# Altium schematic sheet J19B_EM3B_PP0_AFE.SchDoc
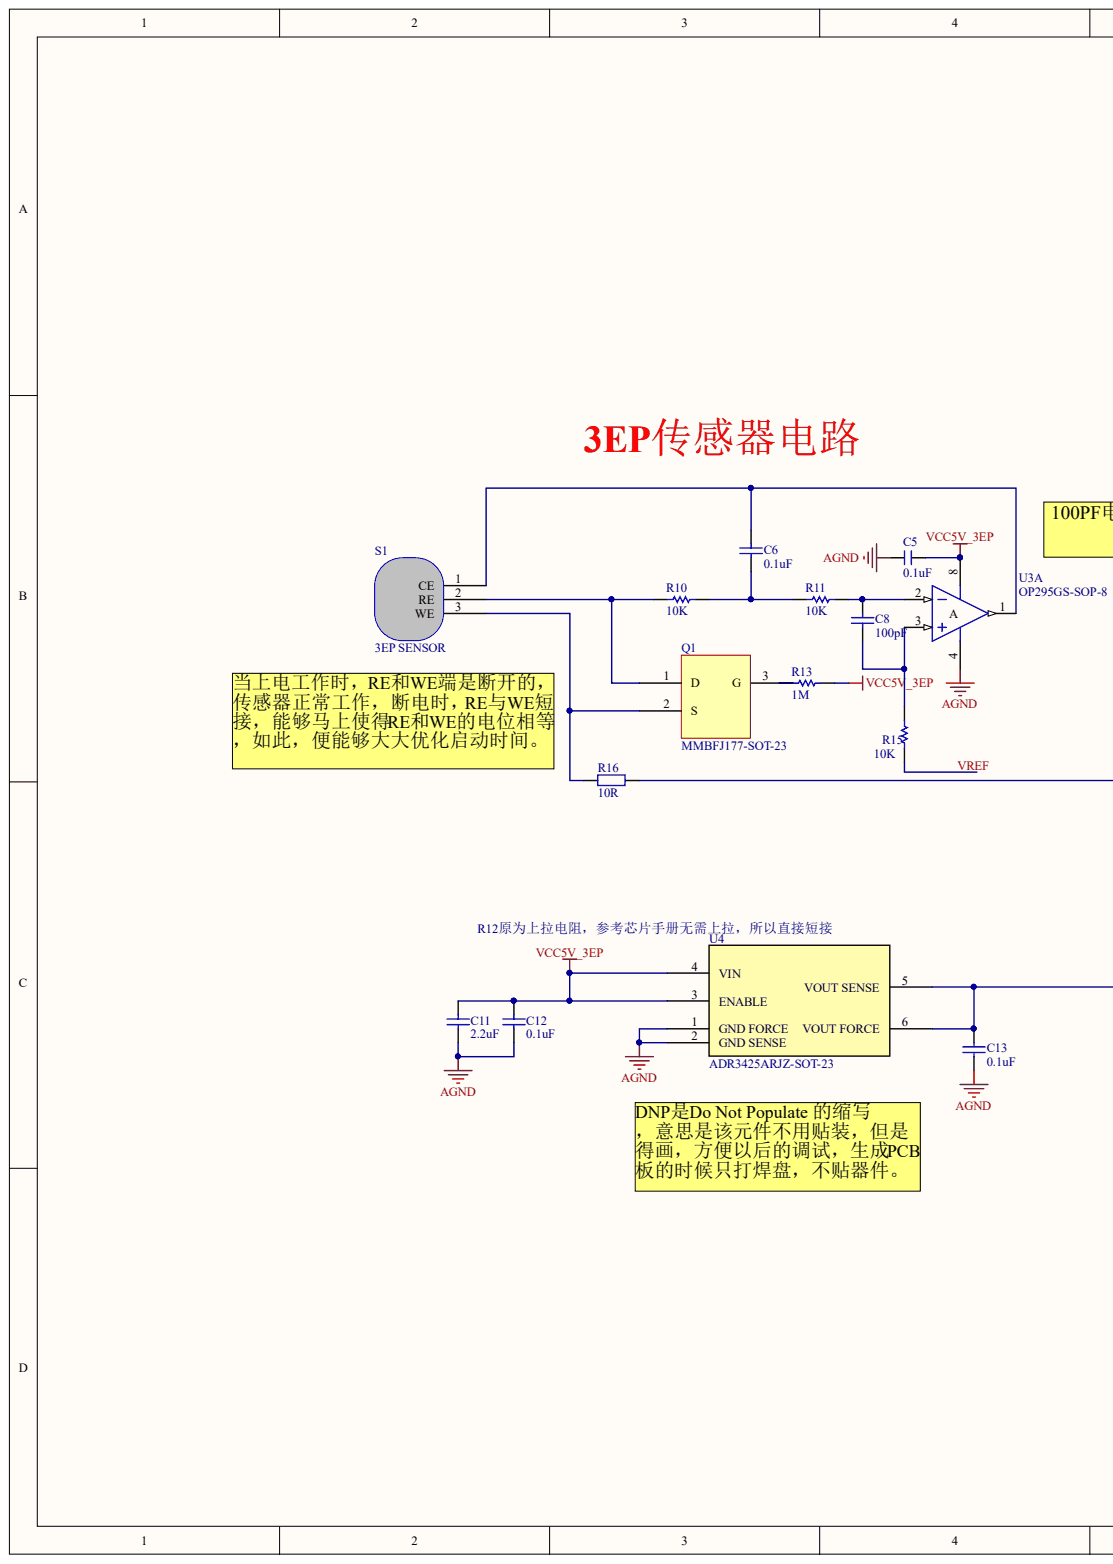
[diagram p0: J19B_EM3B_PP0_AFE.SchDoc, left]
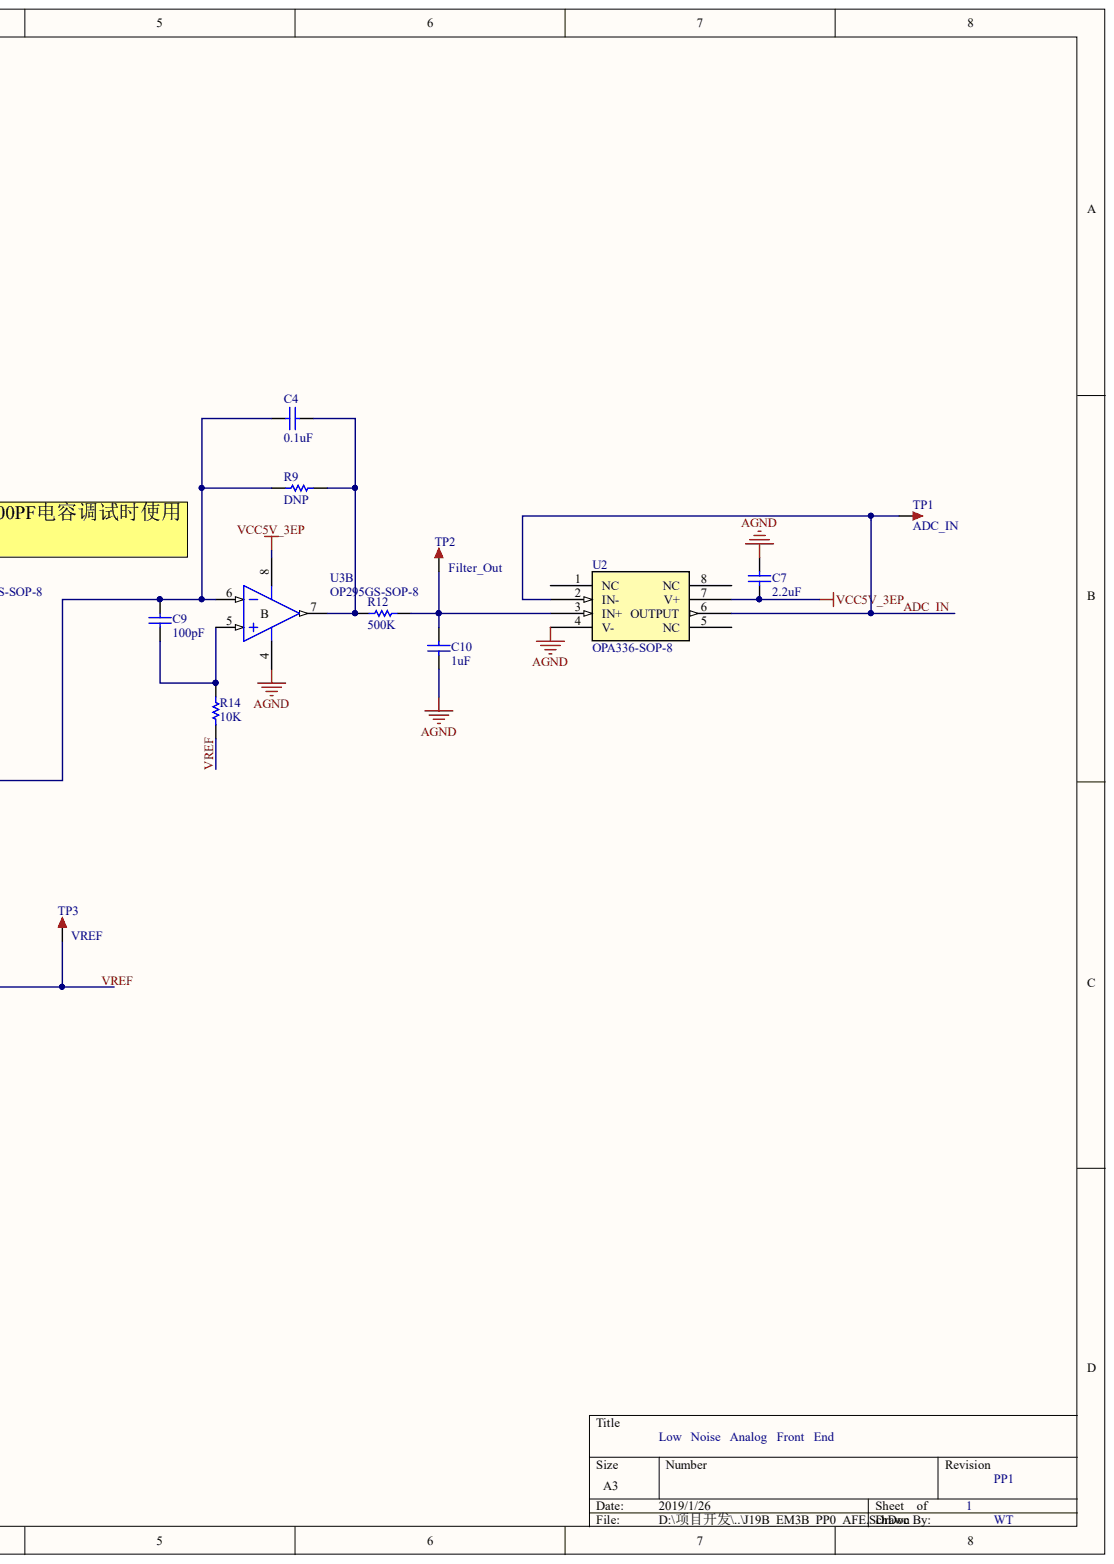
[diagram p1: J19B_EM3B_PP0_AFE.SchDoc, right]

## Parts
C4  0.1uF  [C 0603_L]
C5  0.1uF  [C 0603_L]
C6  0.1uF  [C 0603_L]
C7  2.2uF  [C 0603_L]
C8  100pF  [C 0603_L]
C9  100pF  [C 0603_L]
C10  1uF  [C 0603_L]
C11  2.2uF  [C 0603_L]
C12  0.1uF  [C 0603_L]
C13  0.1uF  [C 0603_L]
Q1  MMBFJ177-SOT-23  [SOT23-3N]
R9  DNP  [R 0603_L]
R10  10K  [R 0603_L]
R11  10K  [R 0603_L]
R12  500K  [R 0603_L]
R13  1M  [R 0603_L]
R14  10K  [R 0603_L]
R15  10K  [R 0603_L]
R16  10R  [R 0603_L]
S1  3EP SENSOR  [COSENSOR]
TP1  ADC_IN  [TEST 2.0]
TP2  Filter_Out  [TEST 2.0]
TP3  VREF  [TEST 2.0]
U2  OPA336-SOP-8  [SOP8_N]
U3  OP295GS-SOP-8  [SOP8_N]  (parts A,B)
U4  ADR3425ARJZ-SOT-23  [SOT23-6N]

## Nets
AGND: C5.1 C7.2 C10.1 C11.1 C12.1 C13.1 U2.4(V-) U3.4 U4.1(GND_FORCE) U4.2(GND_SENSE)
VCC5V_3EP: C5.2 C7.1 C11.2 C12.2 R13.1 U2.7(V+) U3.8 U4.3(ENABLE) U4.4(VIN)
ADC_IN: TP1.1 U2.2(IN-) U2.6(OUTPUT)
VREF: C13.2 R14.1 R15.1 TP3.1 U4.5(VOUT_SENSE) U4.6(VOUT_FORCE)
NetC4_1: C4.1 C9.2 R9.1 R16.2 U3.6
NetC4_2: C4.2 R9.2 R12.1 U3.7
NetC6_1: C6.1 R10.2 R11.1
NetC6_2: C6.2 S1.1 U3.1
NetC8_1: C8.1 R15.2 U3.3
NetC8_2: C8.2 R11.2 U3.2
NetC9_1: C9.1 R14.2 U3.5
NetC10_2: C10.2 R12.2 TP2.1 U2.3(IN+)
NetQ1_1: Q1.1 R10.1 S1.2
NetQ1_2: Q1.2 R16.1 S1.3
NetQ1_3: Q1.3 R13.2

Not connected: U2 1(NC) 5(NC) 8(NC)

## Library data of the parts
C4 C6 C8-C10 C12 C13 = Cap: Capacitor; Component Kind: Standard; LatestRevisionDate: 17-Jul-2002; LatestRevisionNote: Re-released for DXP Platform.; Library Name: Miscellaneous Devices.IntLib; PackageDescription: Radial Cap, Thru-Hole; 2 Leads; 0.3 in Pin Spacing; PackageReference: RAD-0.3; Published: 8-Jun-2000; Publisher: Altium Limited
  C4 C12 C13: Signal Integrity: Cap; Simulation: CAP; Value: 0.1uF
  C6: Value: 0.1uF
  C8 C9: Signal Integrity: Cap; Simulation: CAP; Value: 100pF
  C10: Signal Integrity: Cap; Simulation: CAP; Value: 1uF
C5 = C: 无极性贴片电容; 版权归属: 源创客; BOM名称: 无极性贴片电容; Component Kind: Standard; Library Name: 3.1   - 电阻-电容-电感.SchLib; 学习资源: www.ooocke.com
C7 C11 = Cap: Capacitor; Value: 0.1uF; Component Kind: Standard; LatestRevisionDate: 17-Jul-2002; LatestRevisionNote: Re-released for DXP Platform.; Library Name: Miscellaneous Devices.IntLib; PackageDescription: Radial Cap, Thru-Hole; 2 Leads; 0.3 in Pin Spacing; PackageReference: RAD-0.3; Published: 8-Jun-2000; Signal Integrity: Cap; Simulation: CAP; Publisher: Altium Limited
Q1 = MMBFJ177: Component Kind: Standard; Library Name: MMBFJ177.SchLib
R9 = Res1: Resistor; Value: 62K; Component Kind: Standard; LatestRevisionDate: 17-Jul-2002; LatestRevisionNote: Re-released for DXP Platform.; Library Name: Miscellaneous Devices.IntLib; PackageDescription: Axial Device, Thru-Hole; 2 Leads; 0.3 in Pin Spacing; PackageReference: AXIAL-0.3; Published: 8-Jun-2000; Signal Integrity: Res; Simulation: RESISTOR; Publisher: Altium Limited
R10-R12 R14 R15 = Res1: Resistor; Component Kind: Standard; LatestRevisionDate: 17-Jul-2002; LatestRevisionNote: Re-released for DXP Platform.; PackageDescription: Axial Device, Thru-Hole; 2 Leads; 0.3 in Pin Spacing; PackageReference: AXIAL-0.3; Published: 8-Jun-2000; Signal Integrity: Res; Simulation: RESISTOR; Publisher: Altium Limited
  R10 R14 R15: Library Name: Miscellaneous Devices.IntLib; Value: 10K
  R11: Library Name: Z188_CORE_PP0_180331.IntLib; Value: 10K
  R12: Library Name: Miscellaneous Devices.IntLib; Value: 500K
R13 = Res1: Resistor; Value: 10K; Component Kind: Standard; LatestRevisionDate: 17-Jul-2002; LatestRevisionNote: Re-released for DXP Platform.; Library Name: Miscellaneous Devices.IntLib; PackageDescription: Axial Device, Thru-Hole; 2 Leads; 0.3 in Pin Spacing; PackageReference: AXIAL-0.3; Published: 8-Jun-2000; Signal Integrity: Res; Simulation: RESISTOR; Publisher: Altium Limited
R16 = Res2: Resistor; Component Kind: Standard; LatestRevisionDate: 17-Jul-2002; LatestRevisionNote: Re-released for DXP Platform.; Library Name: Miscellaneous Devices.IntLib; PackageDescription: Axial Device, Thru-Hole; 2 Leads; 0.4 in Pin Spacing; PackageReference: AXIAL-0.4; Published: 8-Jun-2000; Signal Integrity: Res; Simulation: RESISTOR; Value: 10R; Publisher: Altium Limited
S1 = CO SENSOR: Component Kind: Standard; Library Name: CO SENSOR.SchLib
TP1-TP3 = TEST: 测试点; 版权归属: 源创客; BOM名称: 测试点; Component Kind: Standard; Library Name: 15.1 - 未分类器件.SchLib; 学习资源: www.ooocke.com
U2 = OPA336_D_8: Imported; GENERIC_PART_NUMBER: OPA336; Manufacturer: Texas Instruments; FAMILY_NAME: LOW OFFSET VOLTAGE; INDUSTRY_STD_PKG_TYPE: SOIC; PACKAGE_DESIGNATOR: D; PIN_COUNT: 8; Component Kind: Standard; Datasheet_URL: http://www.ti.com/lit/gpn/OPA336; Library Name: OPA336.SchLib; Manufacturer_Part_Number: opa336_d_8; RefDes: RefDes; Type: Type; Value: Value; Vendor: Texas Instruments
U3 = OP295GS: Dual Rail-to-Rail Operational Amplifier; Code_IPC: SOIC127P600-8; Code_JEDEC: MS-012-AA; Component Kind: Standard; ComponentLink1Description: Manufacturer Link; ComponentLink1URL: http://www.analog.com/en/prod/0,,759_786_OP295%2C00.html; ComponentLink2Description: Datasheet; ComponentLink2URL: http://www.analog.com/UploadedFiles/Data_Sheets/32172180016469OP295_459_d.pdf; DatasheetVersion: REV. B 1995; LatestRevisionDate: 13-Apr-2006; LatestRevisionNote: IPC-7351 Footprint Added.; Library Name: AD Operational Amplifier.SchLib; PackageDescription: 8-Pin Small Outline Integrated Circuit 1.27 mm Pitch; PackageReference: SO-8; PackageVersion: revA; PCB3D: SO-8; Published: 8-Jun-2000; Publisher: Altium Limited
U4 = ADR3425ARJZ_R2: Imported; Component Kind: Standard; Library Name: ADR3425.SchLib; RefDes: RefDes; Type: Type; Value: Value
